# Altium schematic sheet Buck_Schematic.schdoc
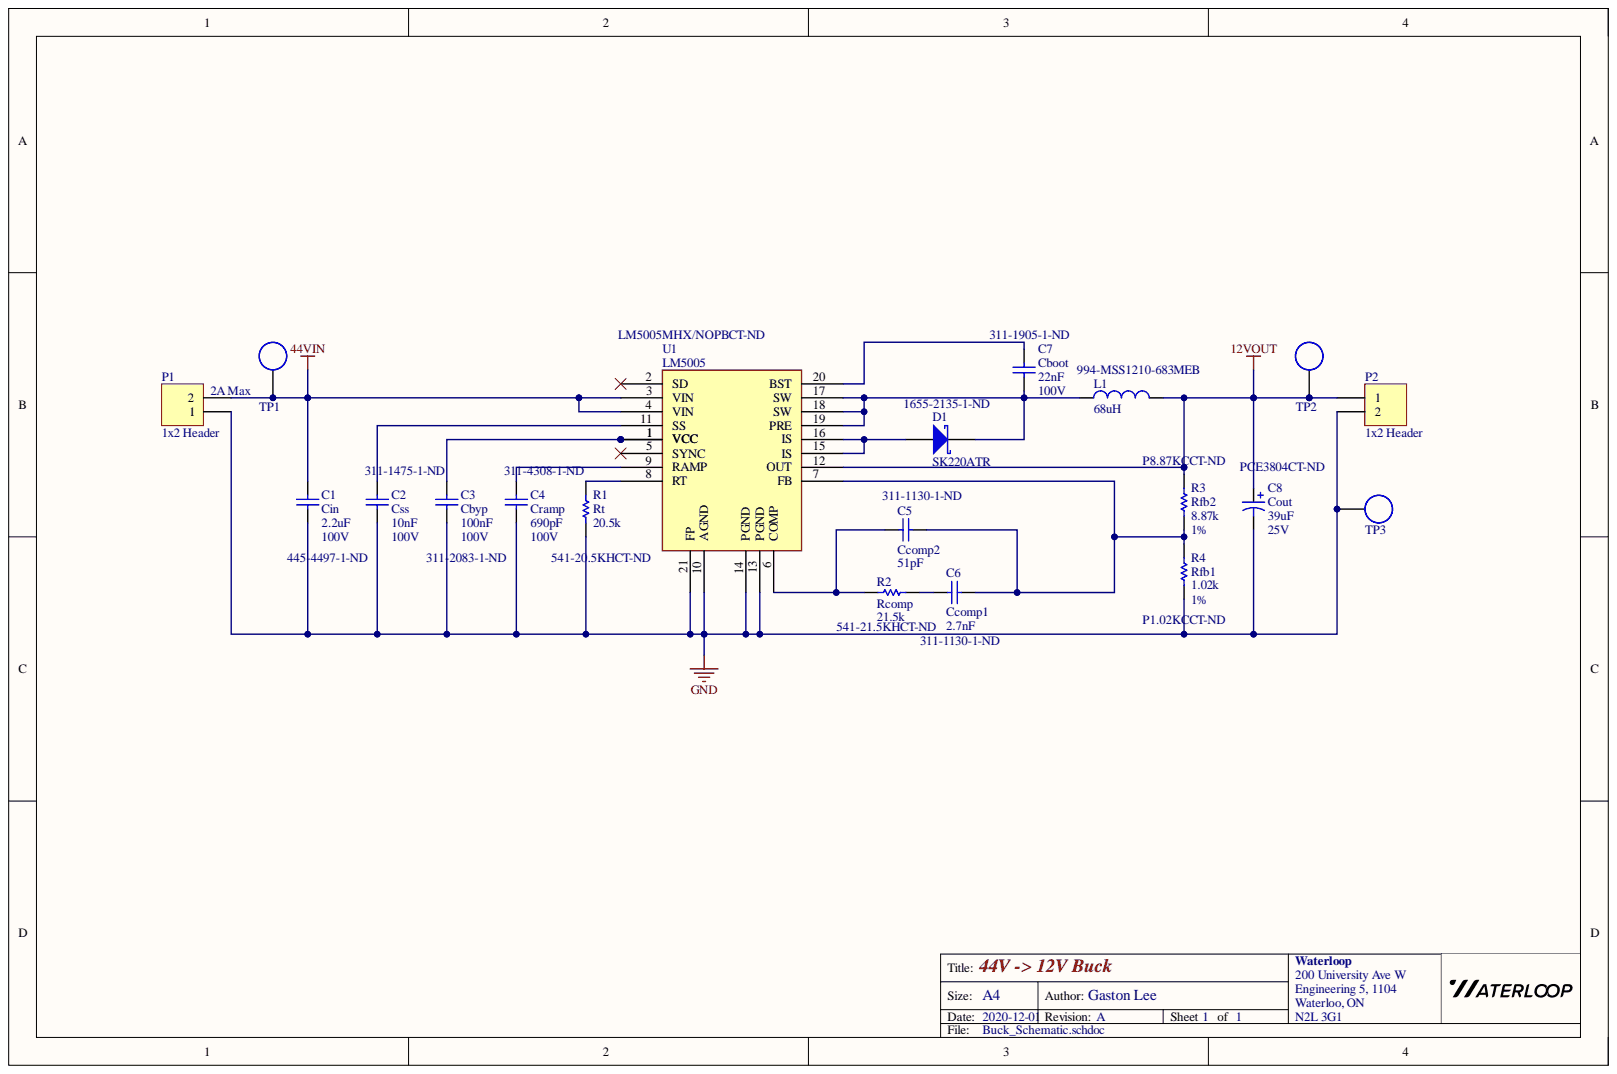
[diagram p0: Buck_Schematic.schdoc]

## Parts
C1  Cin  value 2.2uF  [1210 - Capacitor]
C2  Css  value 10nF  Supplier Part Number 1: 311-1475-1-ND  [0805 - Capacitor]
C3  Cbyp  value 100nF  [0805 - Capacitor]
C4  Cramp  value 690pF  Supplier Part Number 1: 311-4308-1-ND  [0805 - Capacitor]
C5  Ccomp2  value 51pF  Supplier Part Number 1: 311-1130-1-ND  [0805 - Capacitor]
C6  Ccomp1  value 2.7nF  [0805 - Capacitor]
C7  Cboot  value 22nF  Supplier Part Number 1: 311-1905-1-ND  [0805 - Capacitor]
C8  Cout  value 39uF  Supplier Part Number 1: PCE3804CT-ND  [OCVZ0606 - CAPACITOR]
D1  Manufacturer Part Number: SK220ATR  Supplier Part Number 1: 1655-2135-1-ND  [SMA - DIODE]  (hidden comment: Diode - Schottky)
L1  value 68uH  Supplier Part Number 1: 994-MSS1210-683MEB  [MSS1210 - INDUCTOR]  (hidden comment: Inductor)
P1  1x2 Header  [HDR1X2]
P2  1x2 Header  [HDR1X2]
R1  Rt  value 20.5k  [0603 - Resistor]
R2  Rcomp  value 21.5k  [0603 - Resistor]
R3  Rfb2  value 8.87k  Supplier Part Number 1: P8.87KCCT-ND  [0805 - Resistor]
R4  Rfb1  value 1.02k  Supplier Part Number 1: P1.02KCCT-ND  [0805 - Resistor]
TP1  Test Point  [Test Point]  (value not drawn)  (no BOM)
TP2  Test Point  [Test Point]  (value not drawn)  (no BOM)
TP3  Test Point  [Test Point]  (value not drawn)  (no BOM)
U1  LM5005  [LM5005]

## Nets
12VOUT: C8.1 L1.2 P2.1 R3.2 TP2.TP? U1.12(OUT)
44VIN: C1.2 P1.2 TP1.TP? U1.3(VIN) U1.4(VIN)
GND: C1.1 C2.1 C3.1 C4.1 C8.2 P1.1 P2.2 R1.1 R4.1 TP3.TP? U1.10(AGND) U1.13(PGND) U1.14(PGND) U1.21(FP)
NetC2_2: C2.2 U1.11(SS)
NetC3_2: C3.2 U1.1(VCC)
NetC4_2: C4.2 U1.9(RAMP)
NetC5_1: C5.1 C6.1 R3.1 R4.2 U1.7(FB)
NetC5_2: C5.2 R2.2 U1.6(COMP)
NetC6_2: C6.2 R2.1
NetC7_1: C7.1 D1.2 L1.1 U1.17(SW) U1.18(SW) U1.19(PRE)
NetC7_2: C7.2 U1.20(BST)
NetD1_1: D1.1 U1.15(IS) U1.16(IS)
NetR1_2: R1.2 U1.8(RT)

Not connected: U1 2(SD) 5(SYNC)

## Library data of the parts
C1-C7 = Capacitor - Nonpolarized: Nonpolarized Capacitor; Component Kind: Standard; Library Name: General.SchLib; Signal Integrity: Cap; Simulation: CAP; Supplier 1: Digi-Key
  C1: Supplier Part Number 1: 445-4497-1-ND
  C3: Supplier Part Number 1: 311-2083-1-ND
  C6: Supplier Part Number 1: 311-1130-1-ND
C8 = Capacitor - Polarized: Polarized Capacitor; Component Kind: Standard; Library Name: General.SchLib; Signal Integrity: Cap Pol; Simulation: CAP; Supplier 1: Digi-Key
D1 = Diode - Schottky: Schottky Rectifier; Component Kind: Standard; ComponentLink1Description: Manufacturer Link; ComponentLink1URL: http://www.irf.com/; ComponentLink2Description: Datasheet; ComponentLink2URL: http://www.irf.com/product-info/datasheets/data/10tq.pdf; Library Name: General.SchLib; Supplier 1: Digi-Key
P1 P2 = 1x2 Header: 1x2 Pin Header; Component Kind: Standard; Library Name: GeneralConnectors.SchLib; Signal Integrity: Connector
R1-R4 = Resistor: Component Kind: Standard; Description: Resistor; Library Name: General.SchLib; Signal Integrity: Res; Simulation: RESISTOR; Supplier 1: Digi-Key
  R1: Supplier Part Number 1: 541-20.5KHCT-ND
  R2: Supplier Part Number 1: 541-21.5KHCT-ND
U1 = LM5005: 7V to 75V 2.5A, Buck Converter; Manufacturer: Texas Instruments; Manufacturer Part Number: LM5005MHX/NOPB; Component Kind: Standard; Datasheet: https://www.ti.com/lit/ds/symlink/lm5005.pdf?HQS=TI-null-null-digikeymode-df-pf-null-wwe&ts=1606456124909; Library Name: GeneralComponents.IntLib; Supplier: Mouser; Supplier 1: Digi-Key; Supplier Part Number: 926-LM5005MHX/NOPB; Supplier Part Number 1: LM5005MHX/NOPBCT-ND
L1 = Inductor: Component Kind: Standard; Description: Inductor; Library Name: General.SchLib; Signal Integrity: Inductor; Simulation: INDUCTOR; Supplier 1: Mouser
TP1-TP3 = Test Point: Description: Test Point; Library Name: General.SchLib
